# Altium schematic sheet FrontEnd.SchDoc
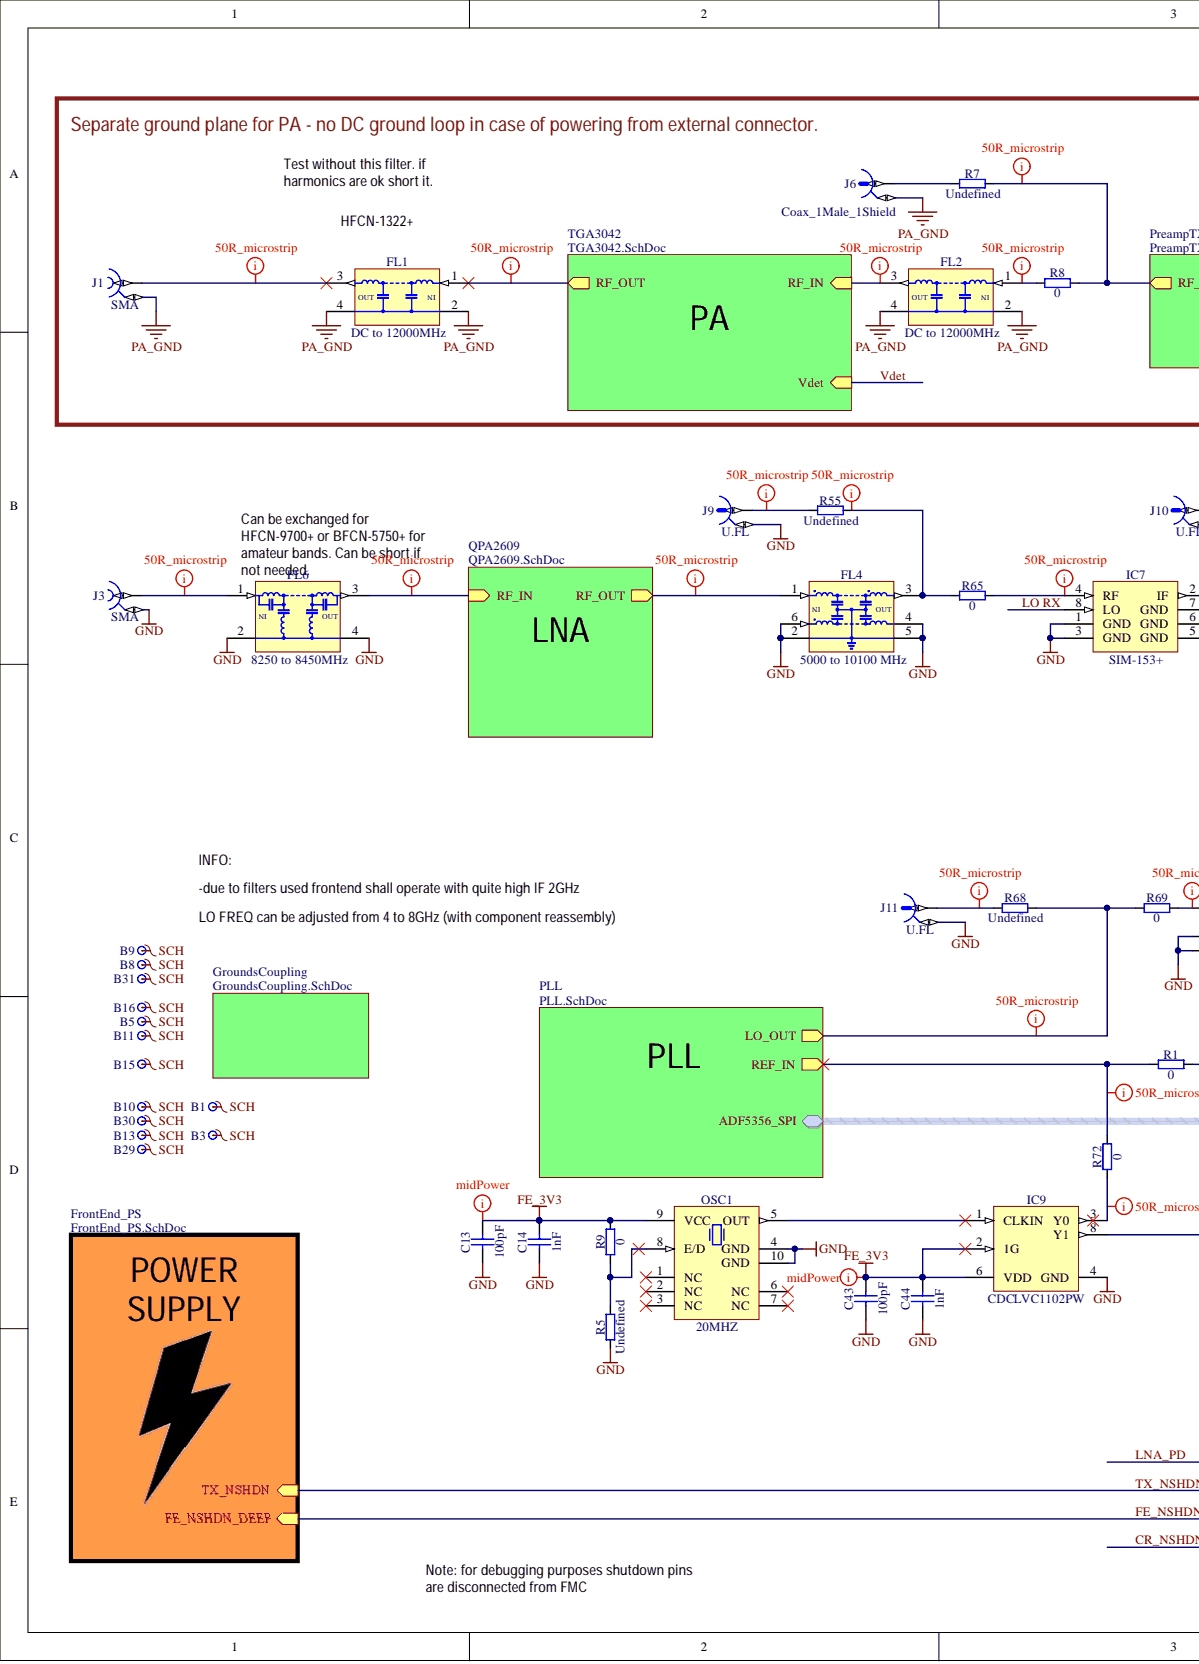
[diagram p0: FrontEnd.SchDoc, left]
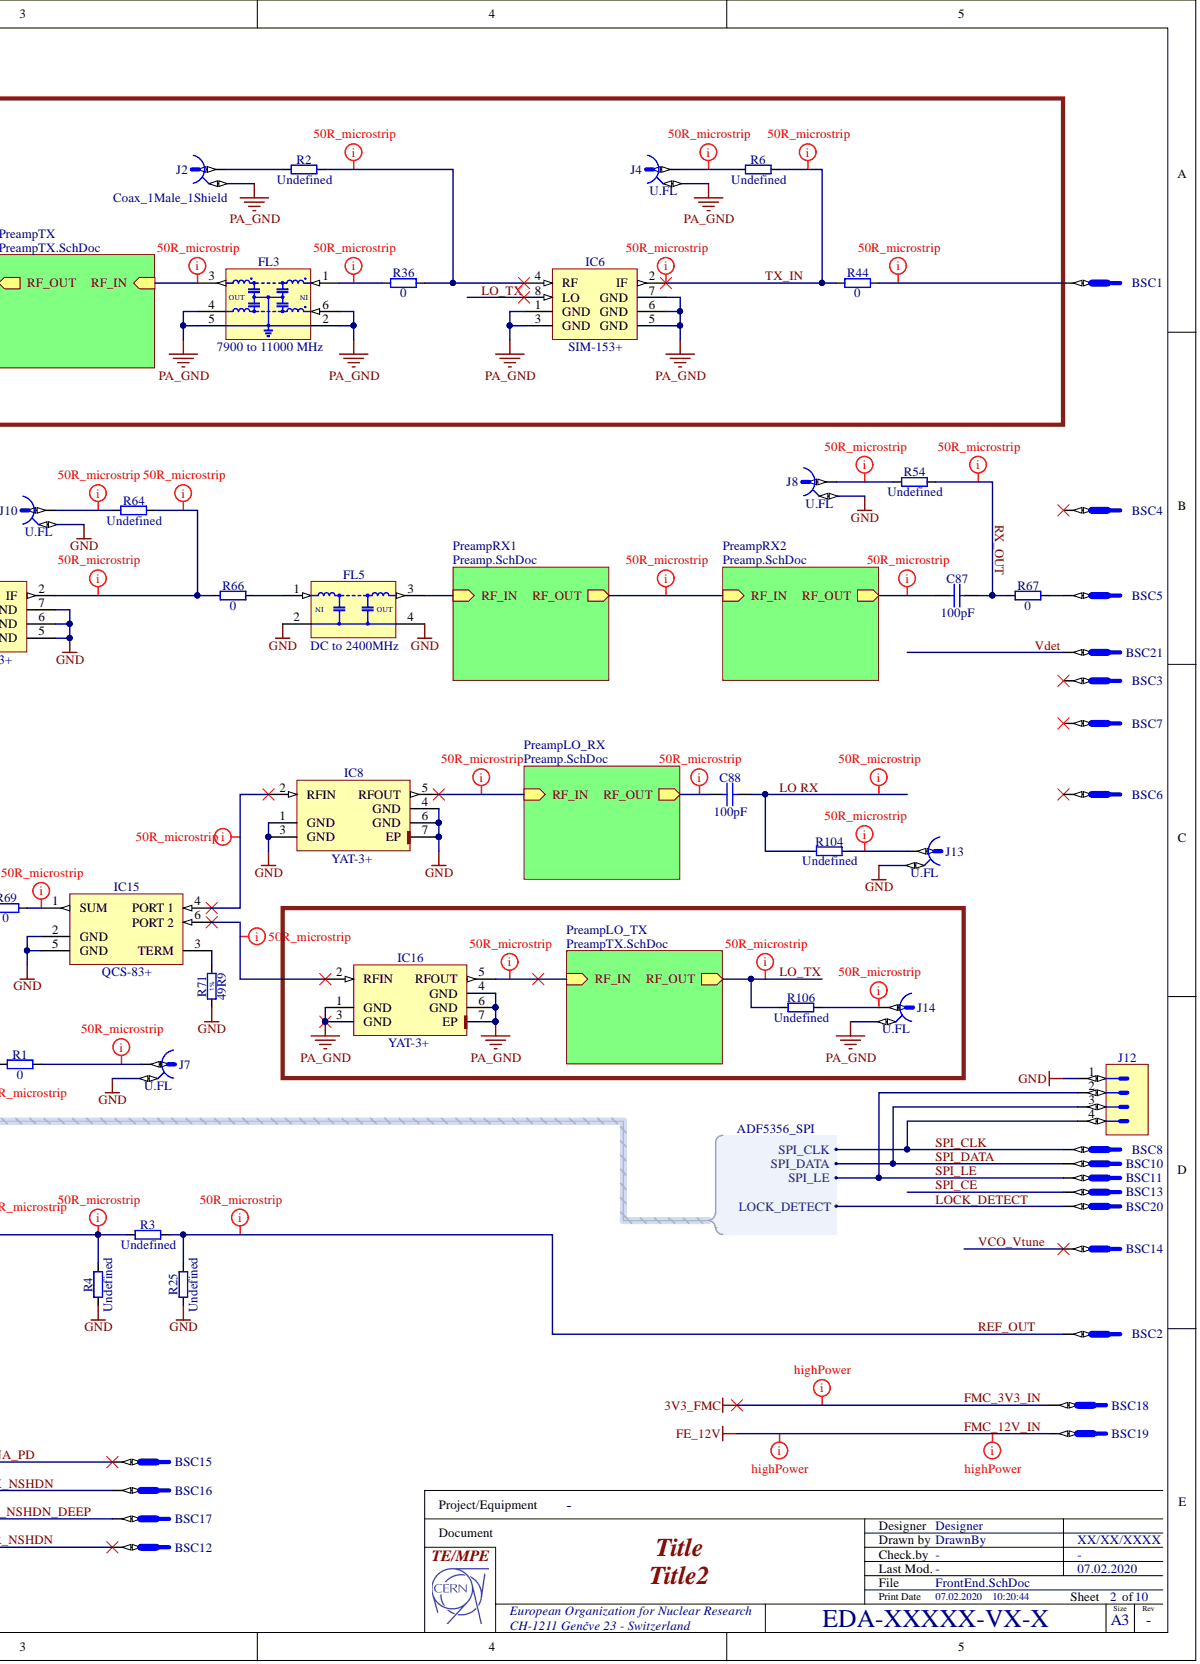
[diagram p1: FrontEnd.SchDoc, right]

## Parts
B1  Plated Hole  [MTG240_400]  (value not drawn)  (no BOM)
B3  Plated Hole  [MTG240_400]  (value not drawn)  (no BOM)
B5  Plated Hole  [MTG240_400]  (value not drawn)  (no BOM)
B8  Plated Hole  [MTG240_400]  (value not drawn)  (no BOM)
B9  Plated Hole  [MTG240_400]  (value not drawn)  (no BOM)
B10  Plated Hole  [MTG240_400]  (value not drawn)  (no BOM)
B11  Plated Hole  [MTG240_400]  (value not drawn)  (no BOM)
B13  Plated Hole  [MTG240_400]  (value not drawn)  (no BOM)
B15  Plated Hole  [MTG240_400]  (value not drawn)  (no BOM)
B16  Plated Hole  [MTG240_400]  (value not drawn)  (no BOM)
B29  Plated Hole  [MTG240_400]  (value not drawn)  (no BOM)
B30  Plated Hole  [MTG240_400]  (value not drawn)  (no BOM)
B31  Plated Hole  [MTG240_400]  (value not drawn)  (no BOM)
BSC1  06031206 Male  (value not drawn)
BSC2  06031206 Male  (value not drawn)
BSC3  06031206 Male  (value not drawn)
BSC4  06031206 Male  (value not drawn)
BSC5  06031206 Male  (value not drawn)
BSC6  06031206 Male  (value not drawn)
BSC7  06031206 Male  (value not drawn)
BSC8  06031206 Male  (value not drawn)
BSC10  06031206 Male  (value not drawn)
BSC11  06031206 Male  (value not drawn)
BSC12  06031206 Male  (value not drawn)
BSC13  06031206 Male  (value not drawn)
BSC14  06031206 Male  (value not drawn)
BSC15  06031206 Male  (value not drawn)
BSC16  06031206 Male  (value not drawn)
BSC17  06031206 Male  (value not drawn)
BSC18  1206 Male  (value not drawn)
BSC19  1206 Male  (value not drawn)
BSC20  06031206 Male  (value not drawn)
BSC21  06031206 Male  (value not drawn)
C13  100pF  [CAPC1005X55N]
C14  1nF  [CAPC1005X55N]
C43  100pF  [CAPC1005X55N]
C44  1nF  [CAPC1005X55N]
C87  100pF  [CAPC1005X55N]
C88  100pF  [CAPC1005X55N]
FL1  DC to 12000MHz  [FIL_MINI-CIRCUITS_FV1206-4]
FL2  DC to 12000MHz  [FIL_MINI-CIRCUITS_FV1206-4]
FL3  7900 to 11000 MHz  [FIL_MINI-CIRCUITS_FV1206-1]
FL4  5000 to 10100 MHz  [FIL_MINI-CIRCUITS_FV1206-1]
FL5  DC to 2400MHz  [FIL_MINI-CIRCUITS_FV1206]
FL6  8250 to 8450MHz  [FIL_MINI-CIRCUITS_FV1206-4]
IC6  SIM-153+  [MINI-CIRCUITS_HV1195]
IC7  SIM-153+  [MINI-CIRCUITS_HV1195]
IC8  YAT-3+  [MINI-CIRCUITS_MC1630]
IC9  CDCLVC1102PW  [SOP65P640X120-8N]
IC15  QCS-83+  [MINI-CIRCUITS_GE0805C-1]
IC16  YAT-3+  [MINI-CIRCUITS_MC1630]
J1  SMA  [TELEGARTNER_J01151A0451]
J2  Coax_1Male_1Shield  [ROSENBERGER_19S103-400L5]
J3  SMA  [TELEGARTNER_J01151A0451]
J4  U.FL  [HIROSE_U.FL-R-SMT-1(10)]
J6  Coax_1Male_1Shield  [ROSENBERGER_19S103-400L5]
J7  U.FL  [HIROSE_U.FL-R-SMT-1(10)]
J8  U.FL  [HIROSE_U.FL-R-SMT-1(10)]
J9  U.FL  [HIROSE_U.FL-R-SMT-1(10)]
J10  U.FL  [HIROSE_U.FL-R-SMT-1(10)]
J11  U.FL  [HIROSE_U.FL-R-SMT-1(10)]
J12  Header 4  [SAMTEC_HW-04-15-L-S-400-150]  (value not drawn)
J13  U.FL  [HIROSE_U.FL-R-SMT-1(10)]
J14  U.FL  [HIROSE_U.FL-R-SMT-1(10)]
OSC1  20MHZ  [OSC_KYOCERA_KT7050A]
R1  0  [RESC1005X40N]
R2  Undefined  [RESC1005X40N]
R3  Undefined  [RESC1005X40N]
R4  Undefined  [RESC1005X40N]
R5  Undefined  [RESC1005X40N]
R6  Undefined  [RESC1005X40N]
R7  Undefined  [RESC1005X40N]
R8  0  [RESC1005X40N]
R9  0  [RESC1005X40N]
R25  Undefined  [RESC1005X40N]
R36  0  [RESC1005X40N]
R44  0  [RESC1005X40N]
R54  Undefined  [RESC1005X40N]
R55  Undefined  [RESC1005X40N]
R64  Undefined  [RESC1005X40N]
R65  0  [RESC1005X40N]
R66  0  [RESC1005X40N]
R67  0  [RESC1005X40N]
R68  Undefined  [RESC1005X40N]
R69  0  [RESC1005X40N]
R71  49R9  [RESC1005X40N]
R72  0  [RESC1005X40N]
R104  Undefined  [RESC1005X40N]
R106  Undefined  [RESC1005X40N]

## Nets
FE_3V3: C13.2 C14.2 C43.2 C44.2 IC9.2(1G) IC9.6(VDD) OSC1.9(VCC) R9.2
GND: C13.1 C14.1 C43.1 C44.1 FL4.2 FL4.4 FL4.5 FL4.6 FL5.2 FL5.4 FL6.2 FL6.4 IC7.1(GND) IC7.3(GND) IC7.5(GND) IC7.6(GND) IC7.7(GND) IC8.1(GND) IC8.3(GND) IC8.4(GND) IC8.6(GND) IC8.7(EP) IC9.4(GND) IC15.2(GND) IC15.5(GND) J3.2 J7.2 J8.2 J9.2 J10.2 J11.2 J12.1 J13.2 OSC1.4(GND) OSC1.10(GND) R4.1 R5.1 R25.1 R71.1
PA_GND: FL1.2 FL1.4 FL2.2 FL2.4 FL3.2 FL3.4 FL3.5 FL3.6 IC6.1(GND) IC6.3(GND) IC6.5(GND) IC6.6(GND) IC6.7(GND) IC16.1(GND) IC16.3(GND) IC16.4(GND) IC16.6(GND) IC16.7(EP) J1.2 J2.2 J4.2 J6.2 J14.2
SCH: B1.1 B3.1 B5.1 B8.1 B9.1 B10.1 B11.1 B13.1 B15.1 B16.1 B29.1 B30.1 B31.1
CR_NSHDN: BSC12.1
FE_NSHDN_DEEP: BSC17.1
FMC_3V3_IN: BSC18.1
FMC_12V_IN: BSC19.1
LNA_PD: BSC15.1
LO RX: C88.2 IC7.8(LO) R104.2
LO_TX: IC6.8(LO) R106.2
LOCK_DETECT: BSC20.1
REF_OUT: BSC2.1 R3.2 R25.2
RX_OUT: C87.2 R54.2 R67.1
SPI_CE: BSC13.1
SPI_CLK: BSC8.1 J12.4
SPI_DATA: BSC10.1 J12.3
SPI_LE: BSC11.1 J12.2
TX_IN: IC6.2(IF) R6.2 R44.1
TX_NSHDN: BSC16.1
VCO_Vtune: BSC14.1
Vdet: BSC21.1
NetBSC1_1: BSC1.1 R44.2
NetBSC3_1: BSC3.1
NetBSC4_1: BSC4.1
NetBSC5_1: BSC5.1 R67.2
NetBSC6_1: BSC6.1
NetBSC7_1: BSC7.1
NetC87_1: C87.1
NetC88_1: C88.1
NetFL1_1: FL1.1
NetFL1_3: FL1.3 J1.1
NetFL2_1: FL2.1 R8.1
NetFL2_3: FL2.3
NetFL3_1: FL3.1 R36.1
NetFL3_3: FL3.3
NetFL4_1: FL4.1
NetFL4_3: FL4.3 R55.2 R65.1
NetFL5_1: FL5.1 R66.2
NetFL5_3: FL5.3
NetFL6_1: FL6.1 J3.1
NetFL6_3: FL6.3
NetIC6_4: IC6.4(RF) R2.2 R36.2
NetIC7_2: IC7.2(IF) R64.2 R66.1
NetIC7_4: IC7.4(RF) R65.2
NetIC8_2: IC8.2(RFIN) IC15.4(PORT_1)
NetIC8_5: IC8.5(RFOUT)
NetIC9_1: IC9.1(CLKIN) OSC1.5(OUT)
NetIC9_3: IC9.3(Y0) R72.1
NetIC9_8: IC9.8(Y1) R3.1 R4.2
NetIC15_1: IC15.1(SUM) R69.2
NetIC15_3: IC15.3(TERM) R71.2
NetIC15_6: IC15.6(PORT_2) IC16.2(RFIN)
NetIC16_5: IC16.5(RFOUT)
NetJ2_1: J2.1 R2.1
NetJ4_1: J4.1 R6.1
NetJ6_1: J6.1 R7.1
NetJ7_1: J7.1 R1.1
NetJ8_1: J8.1 R54.1
NetJ9_1: J9.1 R55.1
NetJ10_1: J10.1 R64.1
NetJ11_1: J11.1 R68.1
NetJ13_1: J13.1 R104.1
NetJ14_1: J14.1 R106.1
NetOSC1_1: OSC1.1(NC)
NetOSC1_2: OSC1.2(NC)
NetOSC1_3: OSC1.3(NC)
NetOSC1_6: OSC1.6(NC)
NetOSC1_7: OSC1.7(NC)
NetOSC1_8: OSC1.8(E/D) R5.2 R9.1
NetR1_2: R1.2 R72.2
NetR7_2: R7.2 R8.2
NetR68_2: R68.2 R69.1
RF_OUT:

## Library data of the parts
B1 B3 B5 B8-B11 B13 B15 B16 B29-B31 = PLATED_HOLE2.4_PAD4.0: Single Terminal Socket; Part Description: Plated Through Hole: Hole Dia.=2.4mm Pad Dia.=4.0mm; Database Table Name: Pads; Mounted: No; ComponentHeight: 0mm; Component Type: Standard (No BOM); CreateDate: 2011-10-10; Family: Plated Hole; LatestRevisionDate: 2011-10-10; Library Name: Eletro-mechanical.DbLib; PackageDescription: Plated Through Hole: Hole Dia.=2.4mm Pad Dia.=4.0mm; Part Number: PLATED_HOLE2.4_PAD4.0; Pin Count: 1; SMD: No; Socket: No; Status: None; Table: Pads; Author: CERN DEM JMW
BSC1-BSC8 BSC10-BSC17 BSC20 BSC21 = (no symbol name): Part Description: Board connection - soldered 0603 jumper; PressFit: No; System: Connector; Pin Count: 1; Sense: No; SMD: Yes; Socket: No; Status: None; Library Name: FMC_SDR.SchLib
BSC18 BSC19 = (no symbol name): Part Description: Board connection - soldered 1206 jumper; PressFit: No; System: Connector; Pin Count: 1; Sense: No; SMD: Yes; Socket: No; Status: None; Library Name: FMC_SDR.SchLib
C13 C43 C87 C88 = CC0402_100PF_50V_5%_C0G: Non-polarised capacitor; Manufacturer: GENERIC; Manufacturer1 Part Number: C0402C101J5GACTU; Part Description: SMD Multilayer Chip Ceramic Capacitor; Database Table Name: Capacitors SMD; TC: C0G/NP0; Tolerance: ±5%; Voltage: 50V; Case: 0402; Component Kind: Standard; Component Type: Standard; CreateDate: 03.12.2007; HelpURL: \\cern.ch\dfs\Applications\Altium\Datasheets\CC0805_C0G_KEMET_(Commercial Grade).pdf; LatestRevisionDate: 22.04.2015; Library Name: Capacitors.DbLib; Manufacturer1 ComponentHeight: 0.55mm; Manufacturer1 Example: KEMET; Manufacturer Part Number: CC0402_100PF_50V_5%_C0G; Mounted: Yes; Part Number: CC0402_100PF_50V_5%_C0G; Pin Count: 2; Sense: No; SMD: Yes; Socket: No; Status: Preferred; Value: 100pF; Author: CERN DEM JLC
C14 C44 = CC0402_1NF_50V_10%_X7R: Non-polarised capacitor; Manufacturer: GENERIC; Manufacturer1 Part Number: 223858715623; Part Description: SMD Multilayer Chip Ceramic Capacitor; Database Table Name: Capacitors SMD; TC: X7R; Tolerance: ±10%; Voltage: 50V; Case: 0402; Component Kind: Standard; Component Type: Standard; CreateDate: 03.12.2007; HelpURL: \\cern.ch\dfs\Applications\Altium\Datasheets\CC0402_X7R_PHYCOMP.pdf; LatestRevisionDate: 03.12.2007; Library Name: Capacitors.DbLib; Manufacturer1 ComponentHeight: 0.55mm; Manufacturer1 Example: PHYCOMP; Manufacturer Part Number: CC0402_1NF_50V_10%_X7R; Mounted: Yes; Part Number: CC0402_1NF_50V_10%_X7R; Pin Count: 2; Sense: No; SMD: Yes; Socket: No; Status: Not Recommended; Value: 1nF; Author: CERN DEM JLC
FL1 FL2 = FIL_MINI-CIRCUITS_LFCN-123+: 50 Ohm DC to 12000MHz Low Pass Filter; Part Description: 50 Ohm Low Pass Filter; Database Table Name: Inductors SMD; ComponentHeight: 1.04mm; Component Kind: Standard; Component Type: Standard; CreateDate: 20.04.2015; HelpURL: \\cern.ch\dfs\Applications\Altium\Datasheets\FIL_MINI-CIRCUITS_LFCN-123+.pdf; LatestRevisionDate: 20.04.2015; Library Name: Inductors & Transformers.DbLib; Manufacturer: MINI-CIRCUITS; Manufacturer Part Number: LFCN-123+; Mounted: Yes; Part Number: FIL_MINI-CIRCUITS_LFCN-123+; Pin Count: 2; Sense: No; SMD: Yes; Socket: No; Status: None; Value: DC to 12000MHz; Author: CERN DEM JLC
FL3 = (no symbol name): 50 Ohm 7900 to 11000 MHz  Bandpass Filter; Manufacturer: MINI-CIRCUITS; Manufacturer Part Number: HFCN-7150+; Part Description: 50 Ohm Dual Low Pass Filter; Part Number: FIL_MINI-CIRCUITS_HFCN-7150+; ComponentHeight: 0.89mm; Component Kind: Standard; Component Type: Standard; CreateDate: 27.10.2019; HelpURL: \\cern.ch\dfs\Applications\Altium\Datasheets\FIL_MINI-CIRCUITS_LFCN-291-1PM+.pdf; LatestRevisionDate: 29.03.2018; Mounted: Yes; Pin Count: 2; Sense: No; SMD: Yes; Socket: No; Status: None; Value: 7900 to 11000 MHz; Author: SH based on CERN; Library Name: FMC_SDR.SchLib
FL4 = FIL_MINI-CIRCUITS_HFCN-4400+: 50 Ohm 5000 to 10100 MHz   Bandpass Filter; Part Description: 50 Ohm Dual Band Pass Filter; ComponentHeight: 0.89mm; Component Kind: Standard; Component Type: Standard; CreateDate: 27.10.2019; HelpURL: \\cern.ch\dfs\Applications\Altium\Datasheets\FIL_MINI-CIRCUITS_LFCN-291-1PM+.pdf; LatestRevisionDate: 27.10.2017; Manufacturer: MINI-CIRCUITS; Manufacturer Part Number: HFCN-4400+; Mounted: Yes; Part Number: FIL_MINI-CIRCUITS_HFCN-4400+; Pin Count: 2; Sense: No; SMD: Yes; Socket: No; Status: None; Value: 5000 to 10100 MHz; Author: SH based on CERN; Library Name: FMC_SDR.SchLib
FL5 = FIL_MINI-CIRCUITS_LFCN-2400+: 50 Ohm DC to 2400MHz Low Pass Filter; Part Description: 50 Ohm Low Pass Filter; Database Table Name: Inductors SMD; ComponentHeight: 0.94mm; Component Kind: Standard; Component Type: Standard; CreateDate: 05.04.2019; HelpURL: \\cern.ch\dfs\Applications\Altium\Datasheets\FIL_MINI-CIRCUITS_LFCN-2400+.pdf; LatestRevisionDate: 05.04.2019; Library Name: Inductors & Transformers.DbLib; Manufacturer: MINI-CIRCUITS; Manufacturer Part Number: LFCN-2400+; Mounted: Yes; Part Number: FIL_MINI-CIRCUITS_LFCN-2400+; Pin Count: 4; Sense: No; SMD: Yes; Socket: No; Status: None; Value: DC to 2400MHz; Author: CERN DEM MR
FL6 = FIL_MINI-CIRCUITS_BFCN-8350+: 50 Ohm 8250 to 8450MHz Band Pass Filter; Part Description: 50 Ohm Band Pass Filter; Database Table Name: Inductors SMD; ComponentHeight: 1.04mm; Component Kind: Standard; Component Type: Standard; CreateDate: 05.04.2019; HelpURL: \\cern.ch\dfs\Applications\Altium\Datasheets\FIL_MINI-CIRCUITS_BFCN-8350+.pdf; LatestRevisionDate: 05.04.2019; Library Name: Inductors & Transformers.DbLib; Manufacturer: MINI-CIRCUITS; Manufacturer Part Number: BFCN-8350+; Mounted: Yes; Part Number: FIL_MINI-CIRCUITS_BFCN-8350+; Pin Count: 4; Sense: No; SMD: Yes; Socket: No; Status: None; Value: 8250 to 8450MHz; Author: CERN DEM MR
IC6 IC7 = (no symbol name): Level 7 (LO Power +7 dBm) 3400 to 15000 MHz; Manufacturer: MINI-CIRCUITS; Part Description: Frequency Mixer - Level 13 (LO Power +13 dBm) 7300 to 20000MHz; Bonding: No; PressFit: No; ComponentHeight: 2.21mm; Component Kind: Standard; Component Type: Standard; CreateDate: 29.10.2014; Device: SIM-153+; HelpURL: \\cern.ch\dfs\Applications\Altium\Datasheets\SIM-24MH+.pdf; LatestRevisionDate: 29.10.2014; Manufacturer Part Number: SIM-153+; Mounted: Yes; PackageDescription: MINI-CIRCUITS HV1195 Case, 1.27mm pitch; 8 pin, 4.57mm W X 5.08mm L; Part Number: SIM-153+; Pin Count: 8; Sense: No; SMD: Yes; Socket: No; Author: CERN DEM JLC; Library Name: FMC_SDR.SchLib
IC8 IC16 = YAT-3+: DC to 18GHz, 2W, 50 Ohm, 4dB Attenuator; Manufacturer: MINI-CIRCUITS; Part Description: DC to 18GHz,  2W, 50 Ohms, 3dB Attenuator; Bonding: No; Database Table Name: Analog & Interface; PressFit: No; ComponentHeight: 0.85mm; Component Kind: Standard; Component Type: Standard; CreateDate: 20.04.2015; Device: YAT-3+; HelpURL: \\cern.ch\dfs\Applications\Altium\Datasheets\YAT-3+.pdf; LatestRevisionDate: 20.04.2015; Library Name: ICs And Semiconductors.DbLib; Manufacturer Part Number: YAT-3+; Mounted: Yes; PackageDescription: MINI-CIRCUITS MC1630 Case, 0.65mm pitch, 6 pin, 2mm X 2mm X 0.85mm H body (w/thermal tab 0.65 X 1.25mm); Part Number: YAT-3+; Pin Count: 7; Sense: No; SMD: Yes; Socket: No; Author: CERN DEM JLC
IC9 = CDCLVC1102PW: 3.3 V and 2.5 V LVCMOS 1:2  High-Performance Clock Buffer; Manufacturer: TEXAS INSTRUMENTS; Bonding: No; Database Table Name: Logic; PressFit: No; Case: TSSOP8; ComponentHeight: 1.2mm; Component Kind: Standard; Component Type: Standard; CreateDate: 28.02.2011; Device: CDCLVC1102PW; HelpURL: \\cern.ch\dfs\Applications\Altium\Datasheets\CDCLVC1102PW.pdf; LatestRevisionDate: 28.02.2011; Library Name: ICs And Semiconductors.DbLib; Manufacturer Part Number: CDCLVC1102PW; Mounted: Yes; PackageDescription: SOP, 0.65mm pitch; 8 pin, 4.40mm W X 3.00mm L X 1.20mm H body, IPC Medium Density; Part Description: 3.3 V and 2.5 V LVCMOS 1:2 High-Performance Clock Buffer; Part Number: CDCLVC1102PW; Pin Count: 8; Sense: No; SMD: Yes; Socket: No; Author: CERN DEM JLC
IC15 = (no symbol name): 2 Way-90° Power Splitter,50Ω 4000 to 8000 MHz; Manufacturer: MINI-CIRCUITS; Part Description: 2 Way-0°, 50 Ohms, 1 to 650MHz, Power Splitter/Combiner; Bonding: No; PressFit: No; ComponentHeight: 0.84mm; Component Kind: Standard; Component Type: Standard; CreateDate: 27.10.2019; Device: QCS-83+; HelpURL: \\cern.ch\dfs\Applications\Altium\Datasheets\ADP-2-1W+.pdf; LatestRevisionDate: 27.10.2019; Manufacturer Part Number: QCS-83+; Mounted: Yes; PackageDescription: MINI-CIRCUITS_GE0805C-1; Part Number: QCS-83+; Pin Count: 6; Sense: No; SMD: Yes; Socket: No; Author: SH based on CERN; Library Name: FMC_SDR.SchLib
J1 J3 = (no symbol name): Manufacturer: TELEGARTNER; Manufacturer Part Number: J01151A0451; Part Description: SMA 50 ohms Edge Mount Bulkhead Jack Receptacle; PressFit: No; ComponentHeight: 7mm; Component Kind: Standard; Component Type: Standard; CreateDate: 27/10/2016; Family: SMA; HelpURL: \\cern.ch\dfs\Applications\Altium\Datasheets\TELEGARTNER_J01151A0451.pdf; LatestRevisionDate: 27/10/2016; Mounted: Yes; Part Number: TELEGARTNER_J01151A0451; Pin Count: 2; Sense: No; SMD: No; Socket: No; Status: None; Author: CERN DEM JLC; Library Name: FMC_SDR.SchLib
J2 J6 = ROSENBERGER_19S103-400L5: Part Description: SMP Straight Plug PCB, 50 Ohms, DC to 26.5GHz; Database Table Name: Miscellaneous; PressFit: No; ComponentHeight: 4.1mm; Component Kind: Standard; Component Type: Standard; CreateDate: 29.11.2017; Family: SMP; HelpURL: \\cern.ch\dfs\Applications\Altium\Datasheets\ROSENBERGER_19S103-400L5.pdf; LatestRevisionDate: 29.11.2017; Library Name: Connectors.DbLib; Manufacturer: ROSENBERGER; Manufacturer Part Number: 19S103-400L5; Mounted: Yes; Part Number: ROSENBERGER_19S103-400L5; Pin Count: 5; Sense: No; SMD: Yes; Socket: No; Status: None; Author: CERN DEM JMW
J4 J7-J11 J13 J14 = HIROSE_U.FL-R-SMT-1(10): Manufacturer: HIROSE (HRS); Part Description: Up to 6GHz Ultra Small Surface Mount Male Coaxial Connectors U.FL Series; Database Table Name: Miscellaneous; PressFit: No; ComponentHeight: 1.25mm; Component Kind: Standard; Component Type: Standard; CreateDate: 24.03.2010; Family: U.FL; HelpURL: \\cern.ch\dfs\Applications\Altium\Datasheets\HIROSE_U.FL-R-SMT-1(10).pdf; LatestRevisionDate: 24.03.2010; Library Name: Connectors.DbLib; Manufacturer Part Number: U.FL-R-SMT-1(10); Mounted: Yes; Part Number: HIROSE_U.FL-R-SMT-1(10); Pin Count: 2; Sense: No; SMD: Yes; Socket: No; Status: None; Author: CERN DEM JLC
J12 = SAMTEC_HW-04-15-L-S-400-150: Connector 4 Male; Part Description: 4 Contacts, Pitch 2.54mm, Single Row Straight .Flex Stack, Flexible Board Stacker (Stacker Height = 10.16mm(400), Tail Length 3.81mm (150)); Database Table Name: SAMTEC; PressFit: No; ComponentHeight: 16mm; Component Kind: Standard; Component Type: Standard; CreateDate: 07.06.2018; Family: Header 4; HelpURL: \\cern.ch\dfs\Applications\Altium\Datasheets\SAMTEC_HW-04-15-L-S-400-150.pdf; LatestRevisionDate: 07.06.2018; Library Name: Connectors.DbLib; Manufacturer: SAMTEC; Manufacturer Part Number: HW-04-15-L-S-400-150; Mounted: Yes; Part Number: SAMTEC_HW-04-15-L-S-400-150; Pin Count: 4; Sense: No; SMD: No; Socket: No; Status: None; Author: CERN DEM MR
OSC1 = OSC_20MHZ_KYOCERA_KT7050A20000KAW33TAD: Oscillator KYOCERA; Part Description: 3.3V, ± 0.28ppm, -40°C ..+85°C, Temperature Compensated Crystal Oscillator (TCXO); Bonding: No; Database Table Name: Crystals & Oscillators; PressFit: No; ComponentHeight: 1.7mm; Component Kind: Standard; Component Type: Standard; CreateDate: 01.12.2017; HelpURL: \\cern.ch\dfs\Applications\Altium\Datasheets\OSC_KYOCERA_KT7050.pdf; LatestRevisionDate: 01.12.2017; Library Name: ICs And Semiconductors.DbLib; Manufacturer: KYOCERA; Manufacturer Part Number: KT7050A20000KAW33TAD; Mounted: Yes; PackageDescription: Oscillator KT7050A Series, 10 pins, 5x7mm; Part Number: OSC_20MHZ_KYOCERA_KT7050A20000KAW33TAD; Pin Count: 10; Sense: No; SMD: Yes; Socket: No; Value: 20MHZ; Author: CERN DEM MR
R1 R8 R9 R36 R44 R65-R67 R69 R72 = R0402_0R_JUMPER: Resistor; Manufacturer: GENERIC; Manufacturer1 Part Number: 232270591001L; Part Description: 1A (0.05R Max DC Resistance) Zero Ohm Jumper; Database Table Name: Resistors SMD; PressFit: No; Case: 0402; Component Kind: Standard; Component Type: Standard; CreateDate: 03.12.2007; HelpURL: \\cern.ch\dfs\Applications\Altium\Datasheets\R0402_Phycomp_RC0402.pdf; LatestRevisionDate: 17.10.2012; Library Name: Resistors.DbLib; Manufacturer1 ComponentHeight: 0.4mm; Manufacturer1 Example: YAGEO PHYCOMP; Manufacturer Part Number: R0402_0R_JUMPER; Mounted: Yes; Part Number: R0402_0R_JUMPER; Pin Count: 2; Sense: No; SMD: Yes; Socket: No; Status: Not Recommended; Value: 0; Author: CERN DEM JLC
R2-R7 R25 R54 R55 R64 R68 R104 R106 = R0402_NO-VALUE: Resistor; Part Description: Reserved Footprint For a 0402 (1005) Chip Resistor; Database Table Name: Resistors SMD; Mounted: No; PressFit: No; Case: 0402; Component Kind: Standard; Component Type: Standard; CreateDate: 03.12.2007; HelpURL: Undefined; LatestRevisionDate: 13.03.2008; Library Name: Resistors.DbLib; Manufacturer: Undefined; Manufacturer1 ComponentHeight: Undefined; Manufacturer1 Example: Undefined; Manufacturer1 Part Number: Undefined; Manufacturer Part Number: R0402_NO-VALUE; Part Number: R0402_NO-VALUE; Pin Count: 2; Sense: No; SMD: Yes; Socket: No; Status: None; Value: Undefined; Author: CERN DEM JLC
R71 = R0402_49R9_1%_0.0625W_100PPM: Resistor - 1%; Manufacturer: GENERIC; Manufacturer1 Part Number: 232270674999L or RC0402FR-0749R9L; Part Description: General Purpose Thick Film Chip Resistor; Database Table Name: Resistors SMD; PressFit: No; TC: ±100ppm/°C; Case: 0402; Component Kind: Standard; Component Type: Standard; CreateDate: 24.10.2012; HelpURL: \\cern.ch\dfs\Applications\Altium\Datasheets\R0402_Phycomp_RC0402.pdf; LatestRevisionDate: 24.10.2012; Library Name: Resistors.DbLib; Manufacturer1 ComponentHeight: 0.4mm; Manufacturer1 Example: YAGEO PHYCOMP; Manufacturer Part Number: R0402_49R9_1%_0.0625W_100PPM; Mounted: Yes; Part Number: R0402_49R9_1%_0.0625W_100PPM; Pin Count: 2; Power: 0.0625W; Sense: No; SMD: Yes; Socket: No; Status: Not Recommended; Tolerance: ±1%; Value: 49R9; Author: CERN DEM JLC
